# Altium schematic sheet power.SchDoc
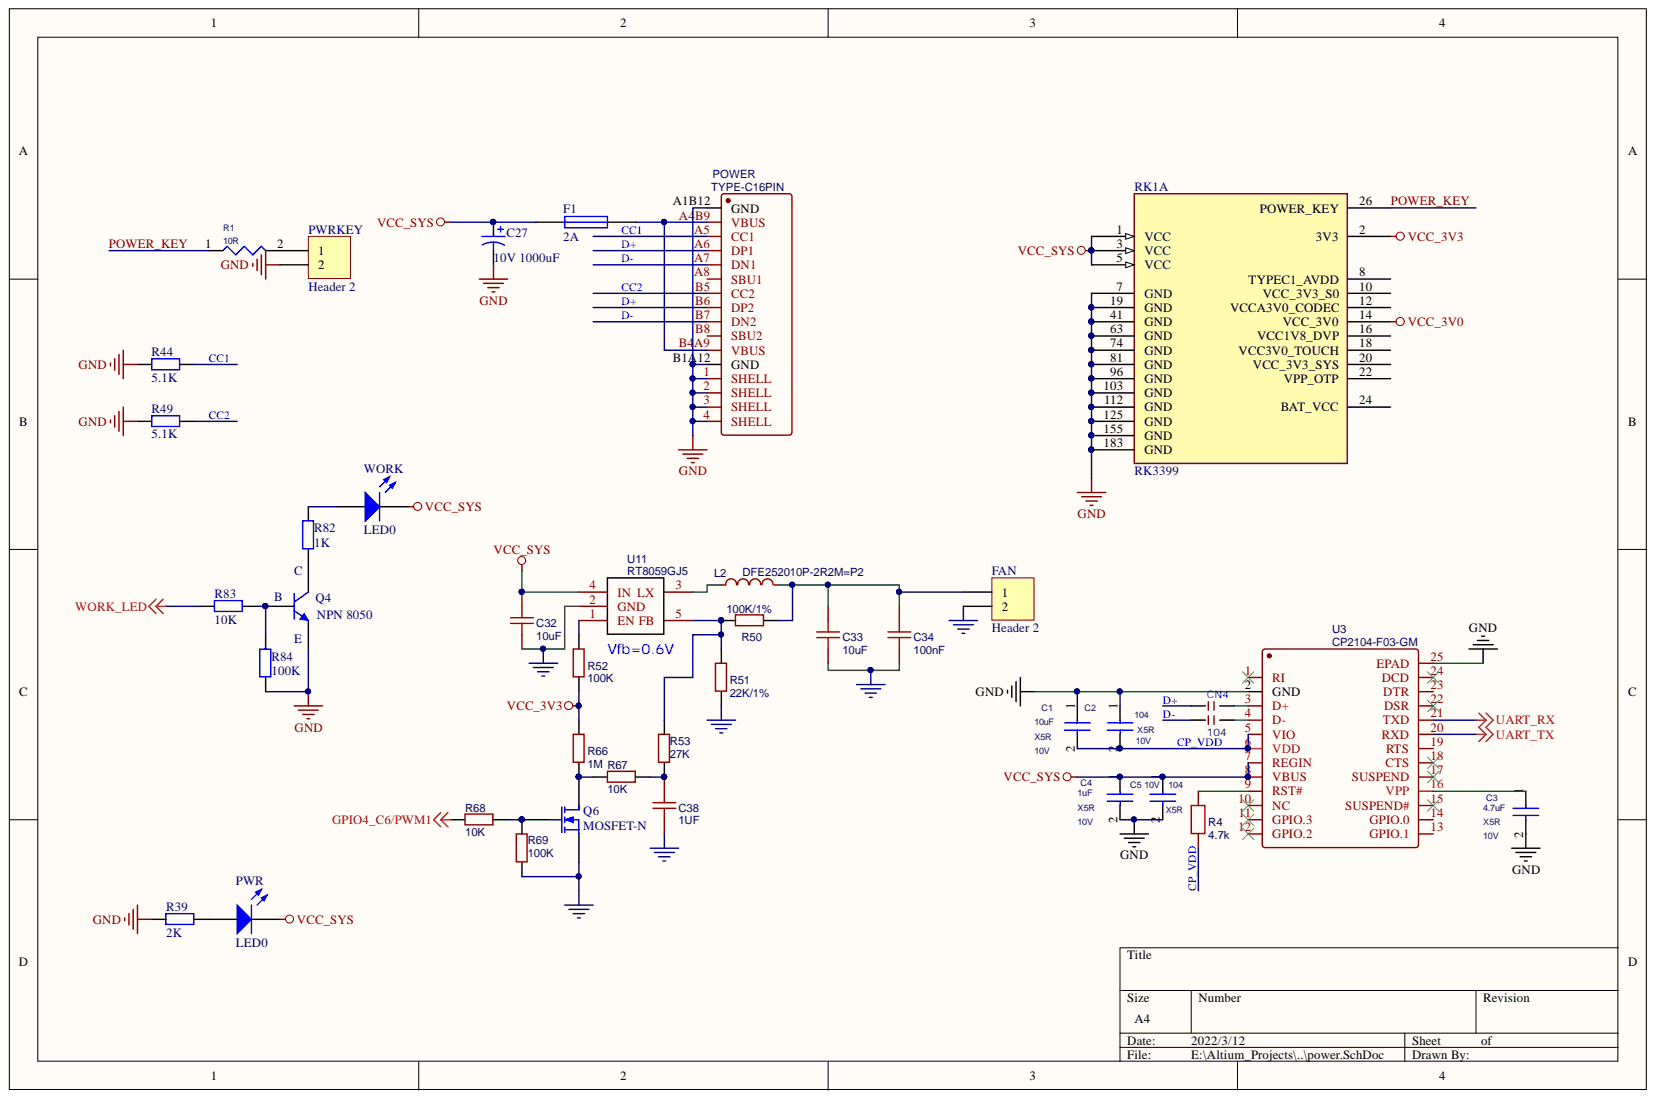
[diagram p0: power.SchDoc]

## Parts
C1  10uF  Dielectric: X5R  Voltage: 10V  [C0603]
C2  104  Dielectric: X5R  Voltage: 10V  [C0402]
C3  4.7uF  Dielectric: X5R  Voltage: 10V  [C0402]
C4  1uF  Dielectric: X5R  Voltage: 10V  [C0402]
C5  104  Dielectric: X5R  Voltage: 10V  [C0402]
C27  10V 1000uF  [CS 5.0*10*13 - R]
C32  10uF  [C0603]
C33  10uF  [C0603]
C34  100nF  [C0402]
C38  1UF  [C0402]
CN4  104  [0402_X2]
F1  2A  [R1210]
FAN  Header 2  [PH2.0-LI-2P]
L2  DFE252010P-2R2M=P2  [CD31]
POWER  TYPE-C16PIN  [USB-C-SMD_TYPE-C16PIN]
PWR  LED0  [LED0603(侧)]
PWRKEY  Header 2  [PH2.0-LI-2P]
Q4  NPN 8050  [SOT_23]
Q6  MOSFET-N  [SOT_323]
R1  10R  [R0402]
R4  4.7k  [R0402]
R39  2K  [R0402]
R44  5.1K  [R0402]
R49  5.1K  [R0402]
R50  100K/1%  [R0402]
R51  22K/1%  [R0402]
R52  100K  [R0402]
R53  27K  [R0402]
R66  1M  [R0402]
R67  10K  [R0402]
R68  10K  [R0402]
R69  100K  [R0402]
R82  1K  [R0402]
R83  10K  [R0402]
R84  100K  [R0402]
RK1  RK3399  [DDR3_SO-DIMM_SHIELDED]  (part A)
U3  CP2104-F03-GM  [QFN-24_L4.0-W4.0-P0.50-BL-EP2.8]
U11  RT8059GJ5  [SOT_23_5]
WORK  LED0  [LED0603(侧)]

## Nets
GND: C1.1 C2.1 C3.2 C4.2 C5.2 C27.2 C32.1 C33.1 C34.1 C38.1 FAN.2 POWER.1(SHELL) POWER.2(SHELL) POWER.3(SHELL) POWER.4(SHELL) POWER.A1B12(GND) POWER.B1A12(GND) PWRKEY.2 Q4.2 Q6.3 R39.1 R44.1 R49.1 R51.1 R69.2 R84.1 RK1.7(GND) RK1.19(GND) RK1.41(GND) RK1.63(GND) RK1.74(GND) RK1.81(GND) RK1.96(GND) RK1.103(GND) RK1.112(GND) RK1.125(GND) RK1.155(GND) RK1.183(GND) U3.2(GND) U3.25(EPAD) U11.2(GND)
GPIO4_C6/PWM1: R68.2
UART_RX: U3.21(TXD)
UART_TX: U3.20(RXD)
VCC_3V0: RK1.14(VCC_3V0)
VCC_3V3: R52.1 R66.2 RK1.2(3V3)
VCC_SYS: C4.1 C5.1 C27.1 C32.2 F1.1 PWR.2 RK1.1(VCC) RK1.3(VCC) RK1.5(VCC) U3.7(REGIN) U3.8(VBUS) U11.4(IN) WORK.2
WORK_LED: R83.1
CC1: POWER.A5(CC1) R44.2
CC2: POWER.B5(CC2) R49.2
CP_VDD: C1.2 C2.2 R4.2 U3.5(VIO) U3.6(VDD)
D+: CN4.3 POWER.A6(DP1) POWER.B6(DP2)
D-: CN4.4 POWER.A7(DN1) POWER.B7(DN2)
POWER_KEY: R1.1 RK1.26(POWER_KEY)
NetC3_1: C3.1 U3.16(VPP)
NetC33_2: C33.2 C34.2 FAN.1 L2.2 R50.2
NetC38_2: C38.2 R53.1 R67.1
NetCN4_1: CN4.1 U3.4(D-)
NetCN4_2: CN4.2 U3.3(D+)
NetF1_2: F1.2 POWER.A4B9(VBUS) POWER.B4A9(VBUS)
NetL2_1: L2.1 U11.3(LX)
NetPWR_1: PWR.1 R39.2
NetPWRKEY_1: PWRKEY.1 R1.2
NetQ4_1: Q4.1 R83.2 R84.2
NetQ4_3: Q4.3 R82.1
NetQ6_1: Q6.1 R66.1 R67.2
NetQ6_2: Q6.2 R68.1 R69.1
NetR4_1: R4.1 U3.9(RST#)
NetR50_1: R50.1 R51.2 R53.2 U11.5(FB)
NetR52_2: R52.2 U11.1(EN)
NetR82_2: R82.2 WORK.1

Not connected: POWER A8(SBU1) B8(SBU2); RK1 8(TYPEC1_AVDD) 10(VCC_3V3_S0) 12(VCCA3V0_CODEC) 16(VCC1V8_DVP) 18(VCC3V0_TOUCH) 20(VCC_3V3_SYS) 22(VPP_OTP) 24(BAT_VCC); U3 1(RI) 10(NC) 11(GPIO.3) 12(GPIO.2) 13(GPIO.1) 14(GPIO.0) 15(SUSPEND#) 17(SUSPEND) 18(CTS) 19(RTS) 22(DSR) 23(DTR) 24(DCD)

## Library data of the parts
C1-C5 = CAP NP_Dup3: cap,10.00uF,+/-10%,10V,X5R,C0805; Manufacturer: TDK; Manufacturer PN: C2012X5R1A106K125AB; Priority: A; Tolerance: 10%; Component Kind: Standard; Part Type: C0805; RK PN: C2012X5R1A106K125AB; Created by: JJJ
C27 = Cap Pol1: Polarized Capacitor (Radial); Component Kind: Standard; LatestRevisionDate: 17-Jul-2002; LatestRevisionNote: Re-released for DXP Platform.; Library Name: Miscellaneous Devices.IntLib; PackageDescription: Polarized Capacitor (Radial); 2 Leads; PackageReference: RB7.6-15; Published: 8-Jun-2000; Signal Integrity: Cap Pol; Simulation: CAP; Value: 10V 1000uF; Publisher: Altium Limited
C32 C33 = 10uF: BOM_Manufacturer: 火炬; BOM_Manufacturer Part: C0805B104K500NT; Contributor: LCSC; nameAlias: Capacitance; BOM_Supplier: LCSC; BOM_Supplier Part: C476766; Component Kind: Standard; spicePre: C; spiceSymbolName: C0805B104K500NT
C34 C38 = 100nF: BOM_Manufacturer: 火炬; BOM_Manufacturer Part: C0805B104K500NT; Contributor: LCSC; nameAlias: Capacitance; BOM_Supplier: LCSC; BOM_Supplier Part: C476766; Component Kind: Standard; spicePre: C; spiceSymbolName: C0805B104K500NT
CN4 = CN2: 排容X2; Component Kind: Standard; Library Name: Schlib1.SchLib
F1 = Fuse 1: Fuse; Component Kind: Standard; LatestRevisionDate: 17-Jul-2002; LatestRevisionNote: Re-released for DXP Platform.; Library Name: Miscellaneous Devices.IntLib; PackageDescription: Thru-Hole; 2 Leads; PackageReference: PIN-W2/E2.8; Published: 8-Jun-2000; Publisher: Altium Limited
FAN PWRKEY = Header 2: Header, 2-Pin; Component Kind: Standard; LatestRevisionDate: 17-Jul-2002; LatestRevisionNote: Re-released for DXP Platform.; Library Name: Miscellaneous Connectors.IntLib; Signal Integrity: Connector; Publisher: Altium Limited
L2 = DFE252010P-2R2M=P2: nameAlias: Value(H); BOM_Manufacturer Part: ?; Component Kind: Standard; spicePre: L; spiceSymbolName: Inductor
POWER = TYPE-C16PIN: BOM_Manufacturer: SHOU HAN; Contributor: LCSC; BOM_Manufacturer Part: TYPE-C16PIN; BOM_Supplier: LCSC; BOM_Supplier Part: C393939; Component Kind: Standard; spicePre: U; spiceSymbolName: TYPE-C16PIN
PWR WORK = LED0: Typical INFRARED GaAs LED; Component Kind: Standard; LatestRevisionDate: 08-Jul-2005; LatestRevisionNote: Mech Layer 1 removed.; Library Name: Miscellaneous Devices.IntLib; PackageDescription: LED; 2 Leads; PackageReference: LED-0; Published: 8-Jun-2000; Publisher: Altium Limited
Q4 = NPN: NPN Bipolar Transistor; Code_JEDEC: TO-226-AA; Component Kind: Standard; LatestRevisionDate: 17-Jul-2002; LatestRevisionNote: Re-released for DXP Platform.; PackageDescription: TO, Flat Index; 3 In-Line, Axial Leads; Body Dia. 4.8mm; Leads 0.55 x 0.51 mm (max); PackageReference: TO-226-AA; Published: 8-Jun-2000; Library Name: jlink.SchLib; Publisher: Altium Limited
Q6 = MOSFET-N: N-Channel MOSFET; Component Kind: Standard; LatestRevisionDate: 17-Jul-2002; LatestRevisionNote: Re-released for DXP Platform.; Library Name: Miscellaneous Devices.IntLib; PackageDescription: TO, Flat Index; 3 In-Line, Axial Leads; Body Dia. 4.6mm; Lead Dia. 0.5 mm (max); PackageReference: E3; PackageVersion: 1995/1996; Published: 8-Jun-2000; Simulation: NMOS; Publisher: Altium Limited
R1 = RESISTOR_Dup2: 通用厚膜电阻,10K,+/-1%,R0402,1/16W.; Manufacturer: YAGEO; Manufacturer PN: RC0402FR-0710KL; Priority: A; Wattage: 1/16W; Component Kind: Standard; Part Type: 通用厚膜电阻; RK PN: RC0402FR-0710KL; Created by: YTL
R4 = 4.7k: Contributor: LCEDA_Lib; nameAlias: Value(Ω); Component Kind: Standard; spicePre: R; spiceSymbolName: R_0603_EU
R39 R44 R49 R82-R84 = Res2: Resistor; Component Kind: Standard; LatestRevisionDate: 17-Jul-2002; LatestRevisionNote: Re-released for DXP Platform.; Library Name: Miscellaneous Devices.IntLib; PackageReference: AXIAL-0.4; Published: 8-Jun-2000; Signal Integrity: Res; Simulation: RESISTOR; Publisher: Altium Limited
  R39: Value: 2K
  R44 R49: Value: 5.1K
  R82: Value: 1K
  R83: Value: 10K
  R84: Value: 100K
R50 = 30K/1%: BOM_Manufacturer: UniOhm; BOM_Manufacturer Part: 0805W8J0103T5E; nameAlias: Resistance (Ohms); BOM_JLCPCB Part Class: Extended Part; BOM_Supplier: LCSC; BOM_Supplier Part: C25612; Component Kind: Standard; spicePre: R; spiceSymbolName: 0805W8J0103T5E
R51-R53 R66-R69 = 6.8K/1%: BOM_Manufacturer: UniOhm; BOM_Manufacturer Part: 0805W8J0103T5E; nameAlias: Resistance (Ohms); BOM_JLCPCB Part Class: Extended Part; BOM_Supplier: LCSC; BOM_Supplier Part: C25612; Component Kind: Standard; spicePre: R; spiceSymbolName: 0805W8J0103T5E
RK1 = RK3399核心板: Component Kind: Standard; Library Name: Schlib1.SchLib
U3 = CP2104-F03-GM: BOM_Manufacturer: SILICON LABS; BOM_Paste Type: base; BOM_Manufacturer Part: CP2104-F03-GM; BOM_Supplier: LCSC; BOM_Supplier Part: C47742; Component Kind: Standard; image: //image.lceda.cn/szlcsc/C47742.jpg; spicePre: U; spiceSymbolName: CP2104-F03-GM
U11 = RT8059GJ5: BOM_Manufacturer: RICHTEK; BOM_Manufacturer Part: RT8059GJ5; BOM_Supplier: LCSC; BOM_Supplier Part: C20542; Component Kind: Standard; spicePre: U
